# Altium schematic sheet [06] - Audio In-Out.SchDoc("[06] - Audio In-Out")
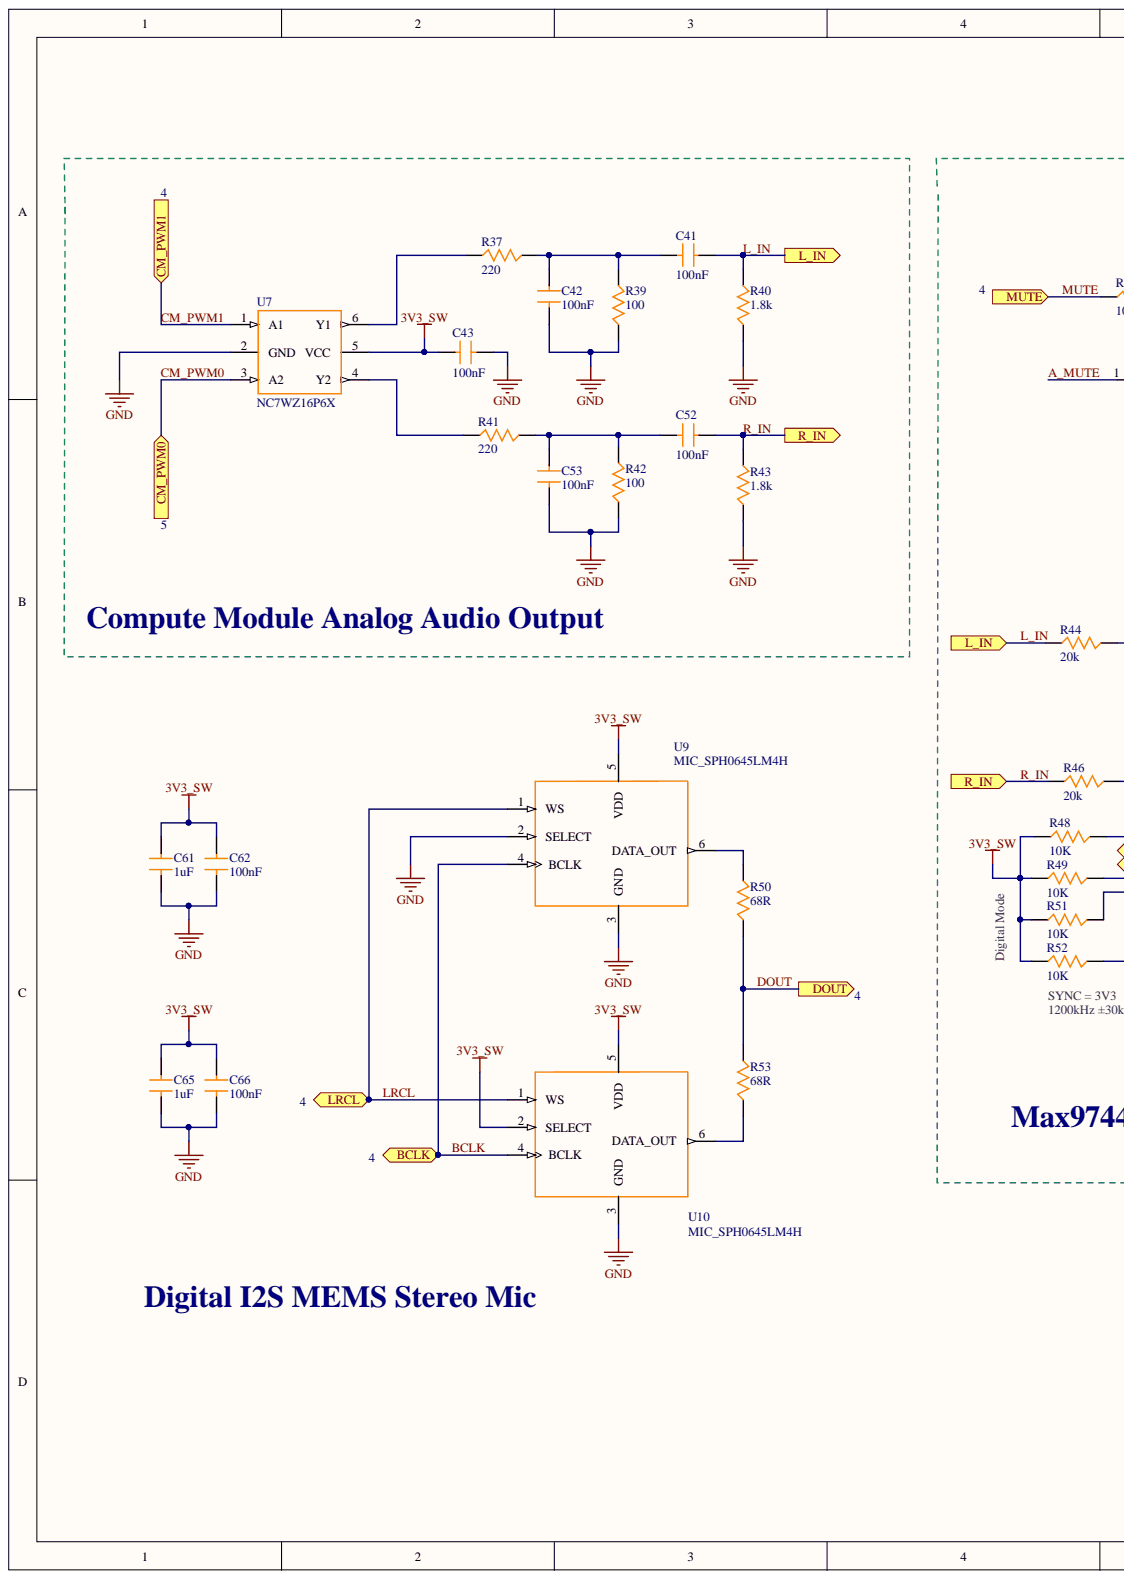
[diagram p0: [06] - Audio In-Out.SchDoc, left]
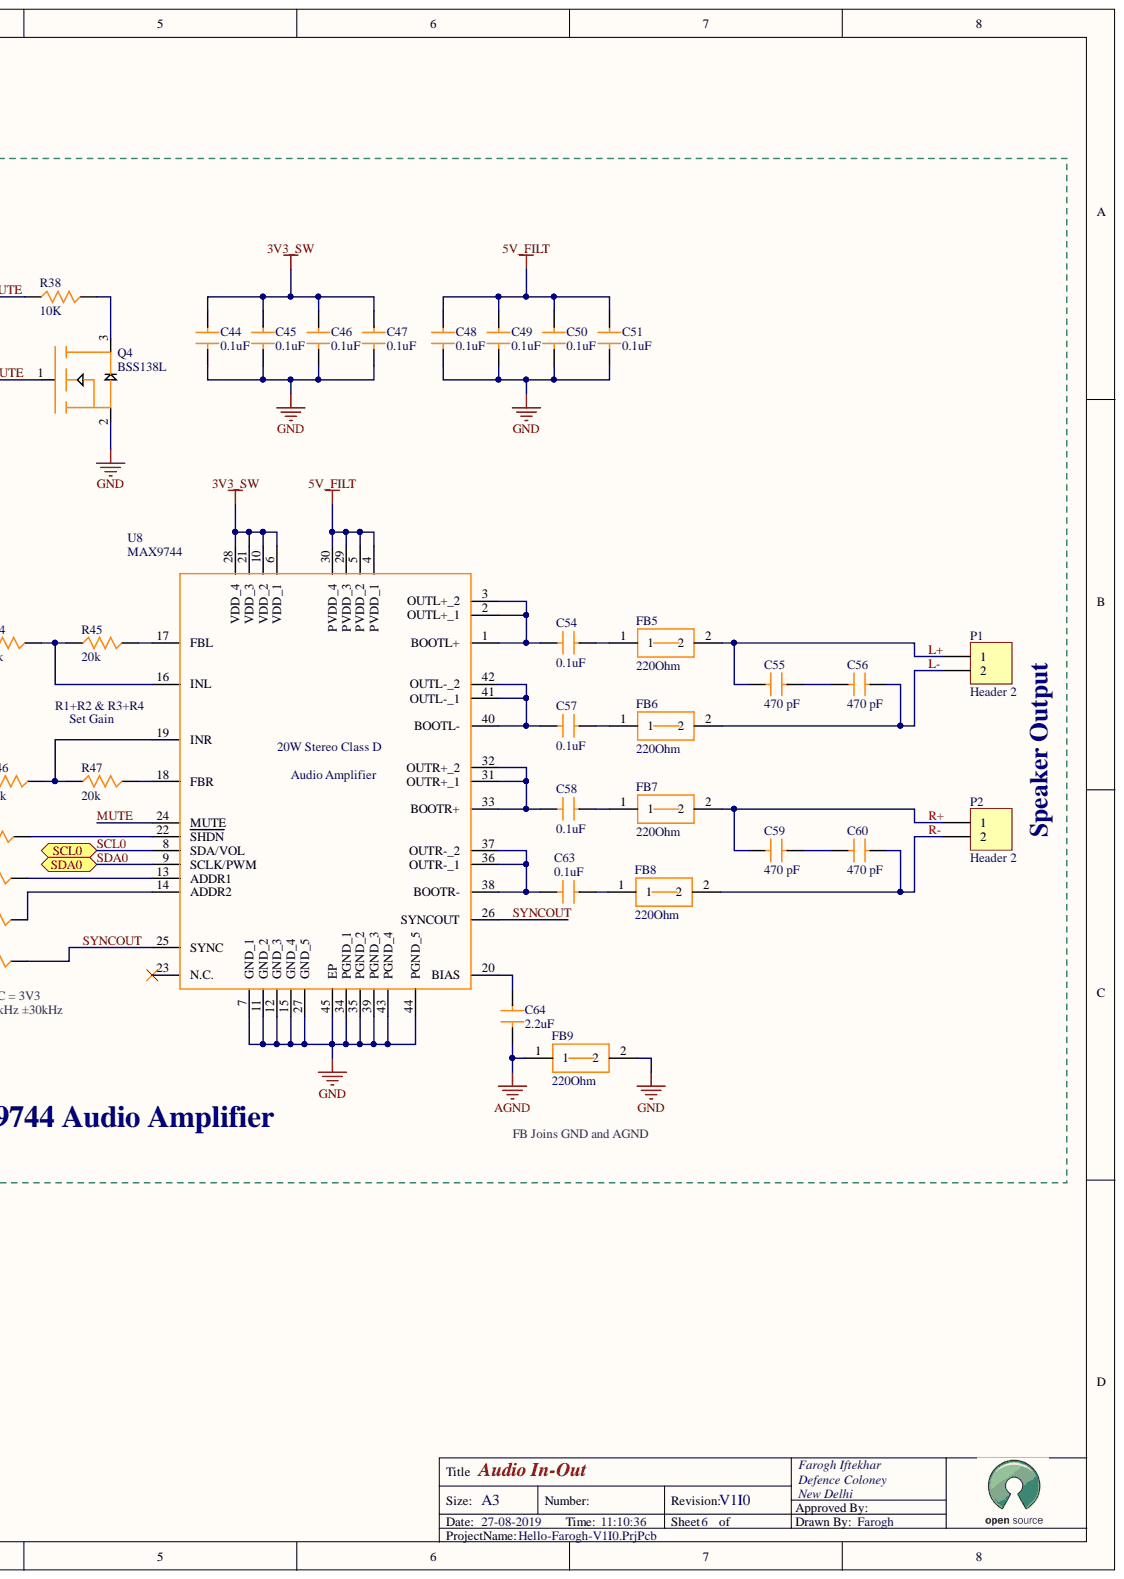
[diagram p1: [06] - Audio In-Out.SchDoc, right]

## Parts
C41  100nF  [C0805]
C42  100nF  [C0805]
C43  100nF  [C0805]
C44  0.1uF  [C0805]
C45  0.1uF  [C0805]
C46  0.1uF  [C0805]
C47  0.1uF  [C0805]
C48  0.1uF  [C0805]
C49  0.1uF  [C0805]
C50  0.1uF  [C0805]
C51  0.1uF  [C0805]
C52  100nF  [C0805]
C53  100nF  [C0805]
C54  0.1uF  [C0805]
C55  470 pF  [C0805]
C56  470 pF  [C0805]
C57  0.1uF  [C0805]
C58  0.1uF  [C0805]
C59  470 pF  [C0805]
C60  470 pF  [C0805]
C61  1uF  [C0805]
C62  100nF  [C0805]
C63  0.1uF  [C0805]
C64  2.2uF  [C1206]
C65  1uF  [C0805]
C66  100nF  [C0805]
FB5  220Ohm  [FB_0805]
FB6  220Ohm  [FB_0805]
FB7  220Ohm  [FB_0805]
FB8  220Ohm  [FB_0805]
FB9  220Ohm  [FB_0805]
P1  Header 2  [HDR1X2]
P2  Header 2  [HDR1X2]
Q4  BSS138L  [BVSS138L]
R37  220  [R0805]
R38  10K  [R0805]
R39  100  [R0805]
R40  1.8k  [R0805]
R41  220  [R0805]
R42  100  [R0805]
R43  1.8k  [R0805]
R44  20k  [R0805]
R45  20k  [R0805]
R46  20k  [R0805]
R47  20k  [R0805]
R48  10K  [R0805]
R49  10K  [R0805]
R50  68R  [R0805]
R51  10K  [R0805]
R52  10K  [R0805]
R53  68R  [R0805]
U7  NC7WZ16P6X  [SOT65P210X110-6N]
U8  MAX9744  [QFN50P700X700X80-45N]
U9  MIC_SPH0645LM4H  [SPH0645LM4H-B]
U10  MIC_SPH0645LM4H  [SPH0645LM4H-B]

## Ports
BCLK, CM_PWM0, CM_PWM1, DOUT, L_IN, LRCL, MUTE, R_IN, SCL0, SDA0

## Nets
3V3_SW: C43.2 C44.2 C45.2 C46.2 C47.2 C61.2 C62.2 C65.2 C66.2 R48.2 R49.2 R51.2 R52.2 U7.5(VCC) U8.6(VDD_1) U8.10(VDD_2) U8.21(VDD_3) U8.28(VDD_4) U9.5(VDD) U10.2(SELECT) U10.5(VDD)
5V_FILT: C48.2 C49.2 C50.2 C51.2 U8.4(PVDD_1) U8.5(PVDD_2) U8.29(PVDD_3) U8.30(PVDD_4)
AGND: C64.1 FB9.1
GND: C42.1 C43.1 C44.1 C45.1 C46.1 C47.1 C48.1 C49.1 C50.1 C51.1 C53.1 C61.1 C62.1 C65.1 C66.1 FB9.2 Q4.2 R39.1 R40.1 R42.1 R43.1 U7.2(GND) U8.7(GND_1) U8.11(GND_2) U8.12(GND_3) U8.15(GND_4) U8.27(GND_5) U8.34(PGND_1) U8.35(PGND_2) U8.39(PGND_3) U8.43(PGND_4) U8.44(PGND_5) U8.45(EP) U9.2(SELECT) U9.3(GND) U10.3(GND)
A_MUTE: Q4.1
BCLK: U9.4(BCLK) U10.4(BCLK) ; port BCLK
CM_PWM0: U7.3(A2) ; port CM_PWM0
CM_PWM1: U7.1(A1) ; port CM_PWM1
DOUT: R50.1 R53.2 ; port DOUT
L+: C55.1 FB5.2 P1.1
L-: C56.2 FB6.2 P1.2
L_IN: C41.2 R40.2 R44.1 ; port L_IN
LRCL: U9.1(WS) U10.1(WS) ; port LRCL
MUTE: R38.2 U8.24(MUTE) ; port MUTE
R+: C59.1 FB7.2 P2.1
R-: C60.2 FB8.2 P2.2
R_IN: C52.2 R43.2 R46.1 ; port R_IN
SCL0: U8.8(SDA/VOL) ; port SCL0
SDA0: U8.9(SCLK/PWM) ; port SDA0
SYNCOUT: R52.1 U8.25(SYNC) U8.26(SYNCOUT)
NetC41_1: C41.1 C42.2 R37.2 R39.2
NetC52_1: C52.1 C53.2 R41.2 R42.2
NetC54_1: C54.1 U8.1(BOOTL+) U8.2(OUTL+_1) U8.3(OUTL+_2)
NetC54_2: C54.2 FB5.1
NetC55_2: C55.2 C56.1
NetC57_1: C57.1 U8.40(BOOTL-) U8.41(OUTL-_1) U8.42(OUTL-_2)
NetC57_2: C57.2 FB6.1
NetC58_1: C58.1 U8.31(OUTR+_1) U8.32(OUTR+_2) U8.33(BOOTR+)
NetC58_2: C58.2 FB7.1
NetC59_2: C59.2 C60.1
NetC63_1: C63.1 U8.36(OUTR-_1) U8.37(OUTR-_2) U8.38(BOOTR-)
NetC63_2: C63.2 FB8.1
NetC64_2: C64.2 U8.20(BIAS)
NetQ4_3: Q4.3 R38.1
NetR37_1: R37.1 U7.6(Y1)
NetR41_1: R41.1 U7.4(Y2)
NetR44_2: R44.2 R45.1 U8.16(INL)
NetR45_2: R45.2 U8.17(FBL)
NetR46_2: R46.2 R47.1 U8.19(INR)
NetR47_2: R47.2 U8.18(FBR)
NetR48_1: R48.1 U8.22(SHDN)
NetR49_1: R49.1 U8.13(ADDR1)
NetR50_2: R50.2 U9.6(DATA_OUT)
NetR51_1: R51.1 U8.14(ADDR2)
NetR53_1: R53.1 U10.6(DATA_OUT)

Not connected: U8 23(N.C.)

## Library data of the parts
C41-C43 C52 C53 C62 C66 = C_0805-100nF-16V: Multilayer Ceramic Capacitors MLCC - SMD/SMT 100nF 16V 20% Ceramic Capacitor; Manufacturer Part Number: CC0805MRX7R7BB104; Brand: Yageo; Capacitance: 0.1 uF; Capacitance - pF: 100000 pF; Class: Class 2; Dielectric: X7R; Product Status: New at Mouser; Type: General Purpose and High Capacitance Surface Mount Ceramic Multilayer Capacitor; Voltage Rating DC: 16 VDC; Capacitance - nF: 100 nF; Case Code - in: 0805; Case Code - mm: 2012; Category: Multilayer Ceramic Capacitors MLCC - SMD/SMT; Component Kind: Standard; ComponentLink1Description: https://www.mouser.com/datasheet/2/447/UPY-GPHC_X7R_6.3V-to-50V_18-1154002.pdf; ComponentLink1URL: https://www.mouser.com/datasheet/2/447/UPY-GPHC_X7R_6.3V-to-50V_18-1154002.pdf; Height: 0.85 mm; Length: 2 mm; Manufacturer: Yageo; Maximum Operating Temperature: + 125 C; Minimum Operating Temperature: - 55 C; Minimum Order Quantity: 1; Package / Case: 0805 (2012 metric); Packaging: Cut Tape; Pricing 1: 1=0.240, 10=0.114, 100=0.057, 1000=0.049, 4000=0.036, 8000=0.034, 24000=0.033, 48000=0.031, 100000=0.026 (USD); Product: General Type MLCCs; Product Type: Ceramic Capacitors; Reeling: True; Series: CC; Standard Pack Qty: 4000; Stock 1: 15850; Subcategory: Capacitors; Supplier 1: Mouser; Supplier Part Number 1: 603-CC0805MRX77BB104; Termination: Standard; Termination Style: SMD/SMT; Tolerance: 20 %; Width: 1.25 mm; Library Name: Joule_Library.IntLib
C44-C51 C54 C57 C58 C63 = C_0805-0.1uF: Multilayer Ceramic Capacitors MLCC - SMD/SMT 0.1uF 10% 50V AEC-Q200; Manufacturer Part Number: AS0805KKX7R9BB104; Brand: Yageo; Capacitance - nF: 100 nF; Capacitance - pF: 100000 pF; CNHTS: 8532241000; Dielectric: X7R; Product Status: New Product; TARIC: 8532240000; Voltage Rating DC: 50 VDC; Capacitance: 0.1 uF; Case Code - in: 0805; Case Code - mm: 2212; Category: Multilayer Ceramic Capacitors MLCC - SMD/SMT; Component Kind: Standard; ComponentLink1Description: http://www.mouser.com/datasheet/2/447/yageodocoutput-1313910.pdf; ComponentLink1URL: http://www.mouser.com/datasheet/2/447/yageodocoutput-1313910.pdf; Height: 1.25 mm; HTS Code: 8532240020; Length: 2 mm; Manufacturer: Yageo; Maximum Operating Temperature: + 125 C; Minimum Operating Temperature: - 55 C; Minimum Order Quantity: 1; Package / Case: 0805 (2012 metric); Packaging: Cut Tape; Pricing 1: 1=0.670, 10=0.461, 100=0.262, 500=0.238, 1000=0.165, 3000=0.156, 6000=0.146, 9000=0.136, 24000=0.122 (USD); Product: Automotive MLCCs; Product Type: Ceramic Capacitors; Reeling: True; RoHS: RoHS Compliant; RoHS - Mouser: Y; Series: AS; Standard Pack Qty: 3000; Stock 1: 30283; Subcategory: Capacitors; Supplier 1: Mouser; Supplier Part Number 1: 603-AS0805KKX79BB104; Termination: Flexible (Soft); Termination Style: SMD/SMT; Tolerance: 10 %; Width: 1.25 mm; Library Name: Joule_Library.IntLib
C55 C56 C59 C60 = C_0805-470 pF: Multilayer Ceramic Capacitors MLCC - SMD/SMT 50V 470pF X7R 0805 10% AEC-Q200; Manufacturer Part Number: C0805S471K5RACAUTO; Brand: KEMET; Capacitance - nF: 0.47 nF; Product Status: New Product; Voltage Rating DC: 50 VDC; Capacitance: 470 pF; Case Code - in: 0805; Case Code - mm: 2012; Category: Multilayer Ceramic Capacitors MLCC - SMD/SMT; Component Kind: Standard; ComponentLink1Description: https://www.mouser.com/datasheet/2/212/KEM_C1014_X7R_FE-CAP_SMD-1102761.pdf; ComponentLink1URL: https://www.mouser.com/datasheet/2/212/KEM_C1014_X7R_FE-CAP_SMD-1102761.pdf; Dielectric: X7R; Height: 0.78 mm; Length: 2 mm; Manufacturer: KEMET; Maximum Operating Temperature: + 125 C; Minimum Operating Temperature: - 55 C; Minimum Order Quantity: 1; Package / Case: 0805 (2012 metric); Packaging: Cut Tape; Pricing 1: 1=0.370, 10=0.217, 100=0.131, 500=0.119, 1000=0.108, 4000=0.079, 8000=0.072, 24000=0.070, 48000=0.062 (USD); Product: Automotive MLCCs; Product Type: Ceramic Capacitors; Qualification: AEC-Q200; Reeling: True; RoHS: RoHS Compliant; RoHS - Mouser: Y; Series: SMD Auto X7R FE; Standard Pack Qty: 4000; Stock 1: 7003; Subcategory: Capacitors; Supplier 1: Mouser; Termination: Standard; Termination Style: SMD/SMT; Tolerance: 10 %; Tradename: FE-CAP; Width: 1.25 mm; Library Name: Joule_Library.IntLib
C61 C65 = C_0805-1uF: Multilayer Ceramic Capacitors MLCC - SMD/SMT 10V 1uF X7R 0805 10%; Manufacturer Part Number: C0805C105K8RAC7210; Brand: KEMET; Capacitance - nF: 1000 nF; Class: Class 2; Product Status: New Product; Voltage Rating DC: 10 VDC; Capacitance: 1 uF; Case Code - in: 0805; Case Code - mm: 2012; Category: Multilayer Ceramic Capacitors MLCC - SMD/SMT; Component Kind: Standard; ComponentLink1Description: https://www.mouser.com/datasheet/2/212/KEM_C1002_X7R_SMD-1102033.pdf; ComponentLink1URL: https://www.mouser.com/datasheet/2/212/KEM_C1002_X7R_SMD-1102033.pdf; Dielectric: X7R; Height: 0.9 mm; Length: 2 mm; Manufacturer: KEMET; Maximum Operating Temperature: + 125 C; Minimum Operating Temperature: - 55 C; Minimum Order Quantity: 1; Package / Case: 0805 (2012 metric); Packaging: Cut Tape; Pricing 1: 1=0.230, 10=0.104, 100=0.064, 500=0.057, 1000=0.048, 2000=0.041, 10000=0.036, 15000=0.032, 45000=0.028 (USD); Product: General Type MLCCs; Product Type: Ceramic Capacitors; Reeling: True; RoHS: RoHS Compliant; RoHS - Mouser: Y; Series: SMD Comm X7R; Standard Pack Qty: 15000; Stock 1: 38596; Subcategory: Capacitors; Supplier 1: Mouser; Supplier Part Number 1: 80-C0805C105K8R7210; Termination: Standard; Termination Style: SMD/SMT; Tolerance: 10 %; Width: 1.25 mm; Library Name: Joule_Library.IntLib
C64 = C_1206-2.2uF: Multilayer Ceramic Capacitors MLCC - SMD/SMT 25V 2.2uF X7R 1206 10%; Manufacturer Part Number: C1206C225K3REC7210; Brand: KEMET; Capacitance - nF: 2200 nF; Class: Class 2; CNHTS: 8532241000; Product Status: New Product; TARIC: 8532240000; Voltage Rating DC: 25 VDC; Capacitance: 2.2 uF; Case Code - in: 1206; Case Code - mm: 3216; Category: Multilayer Ceramic Capacitors MLCC - SMD/SMT; Component Kind: Standard; ComponentLink1Description: http://www.mouser.com/datasheet/2/212/KEM_C1090_X7R_ESD-1103328.pdf; ComponentLink1URL: http://www.mouser.com/datasheet/2/212/KEM_C1090_X7R_ESD-1103328.pdf; Dielectric: X7R; Height: 1.6 mm; HTS Code: 8532240020; Length: 3.2 mm; Manufacturer: KEMET; Maximum Operating Temperature: + 125 C; Minimum Operating Temperature: - 55 C; Minimum Order Quantity: 1; Package / Case: 1206 (3216 metric); Packaging: Cut Tape; Pricing 1: 1=0.230, 10=0.121, 100=0.073, 500=0.065, 1000=0.051, 5000=0.045, 8000=0.041, 24000=0.039, 48000=0.035 (USD); Product: General Type MLCCs; Product Type: Ceramic Capacitors; Reeling: True; RoHS: RoHS Compliant; RoHS - Mouser: Y; Series: ESD SMD Comm X7R; Standard Pack Qty: 8000; Stock 1: 7554; Subcategory: Capacitors; Supplier 1: Mouser; Supplier Part Number 1: 80-C1206C225K3RECLR; Termination: Standard; Termination Style: SMD/SMT; Tolerance: 10 %; Width: 1.6 mm; Library Name: Joule_Library.IntLib
FB5-FB9 = FB_0805-220, 3000mA: Ferrite Beads NEW MFG PN 810-MPZ2012S221AT000; Brand: TDK; Impedance: 220 Ohms; Maximum DC Current: 3 A; Maximum DC Resistance: 40 mOhms; Product Status: Part Number Change; Test Frequency: 100 MHz; Tolerance: 25 %; Type: Chip Bead SMD for Power Line; Capacitance: -; Category: Ferrite Beads; Component Kind: Standard; ComponentLink1Description: https://www.mouser.com/datasheet/2/400/eads_commercial_power_mpz2012_en-923646.pdf; ComponentLink1URL: https://www.mouser.com/datasheet/2/400/eads_commercial_power_mpz2012_en-923646.pdf; Height: 0.85 mm; Length: 2 mm; Manufacturer: TDK; Manufacturer Part Number: MPZ2012S221A; Maximum Operating Temperature: + 125 C; Minimum Operating Temperature: - 55 C; Minimum Order Quantity: 1; Operating Temperature Range: - 55 C to + 125 C; Package / Case: 0805 (2012 metric); Packaging: Cut Tape; Pricing 1: 1=0.120, 10=0.101, 100=0.046, 500=0.039, 1000=0.033, 4000=0.028, 8000=0.024, 48000=0.023 (USD); Product: Ferrite Chip Beads; Product Type: Ferrite Beads; Reeling: True; RoHS: RoHS Compliant; RoHS - Mouser: Y; Series: MPZ; Standard Pack Qty: 4000; Stock 1: 24645; Subcategory: Ferrites; Supplier 1: Mouser; Supplier Part Number 1: 810-MPZ2012S221A; Termination: -; Termination Style: SMD/SMT; Width: 1.25 mm; Library Name: Joule_Library.IntLib
P1 P2 = Header 2: Header, 2-Pin; Component Kind: Standard; LatestRevisionDate: 17-Jul-2002; LatestRevisionNote: Re-released for DXP Platform.; Library Name: Miscellaneous Connectors.IntLib; Signal Integrity: Connector; Publisher: Altium Limited
Q4 = BSS138L: MOSFET FET 50V 3.5 OHM SOT23; Brand: ON Semiconductor / Fairchild; Channel Mode: Enhancement; CNHTS: 8541290000; Configuration: Single; Fall Time: 5.1 ns; Forward Transconductance - Min: 120 mS; Id - Continuous Drain Current: 200 mA; MXHTS: 85412999; Number of Channels: 1 Channel; Pd - Power Dissipation: 350 mW; Qg - Gate Charge: 549 pC; Rise Time: 1.8 ns; Transistor Polarity: N-Channel; Transistor Type: 1 N-Channel; Typical Turn-Off Delay Time: 5.3 ns; Typical Turn-On Delay Time: 2.2 ns; Vgs - Gate-Source Voltage: 20 V; Vgs th - Gate-Source Threshold Voltage: 1.25 V; Category: MOSFET; Component Kind: Standard; ComponentLink1Description: http://www.mouser.com/datasheet/2/308/BSS138L-1300146.pdf; ComponentLink1URL: http://www.mouser.com/datasheet/2/308/BSS138L-1300146.pdf; Height: 1.2 mm; HTS Code: 8541210095; Length: 2.9 mm; Manufacturer: ON Semiconductor / Fairchild; Manufacturer Part Number: BSS138L; Maximum Operating Temperature: + 150 C; Minimum Operating Temperature: - 55 C; Minimum Order Quantity: 1; Mounting Style: SMD/SMT; Package / Case: SOT-23-3; Packaging: Cut Tape; Pricing 1: 1=0.260, 10=0.180, 100=0.075, 1000=0.052, 3000=0.040, 9000=0.035, 24000=0.032, 45000=0.030 (USD); Product Type: MOSFET; Rds On - Drain-Source Resistance: 3.5 Ohms; Reeling: True; RoHS: RoHS Compliant; RoHS - Mouser: Y; Series: BSS138L; Standard Pack Qty: 3000; Stock 1: 227181; Subcategory: MOSFETs; Supplier 1: Mouser; Supplier Part Number 1: 512-BSS138L; TARIC: 8541290000; Technology: Si; Vds - Drain-Source Breakdown Voltage: 50 V; Width: 1.3 mm; Library Name: Joule_Library.IntLib
R37 R41 = R_0805-220: Thin Film Resistors - SMD; Manufacturer Part Number: RT0805DRE07220RL; Brand: Yageo; CNHTS: 8533211000; MXHTS: 85332101; Power Rating: 125 mW (1/8 W); Resistance: 220 Ohms; TARIC: 8533210000; Temperature Coefficient: 50 PPM / C; Tolerance: 0.5 %; Voltage Rating: 150 V; Case Code - in: 0805; Case Code - mm: 2012; Category: Thin Film Resistors - SMD; Component Kind: Standard; ComponentLink1Description: https://www.mouser.com/datasheet/2/447/PYu-RT_1-to-0.01_RoHS_L_9-1222720.pdf; ComponentLink1URL: https://www.mouser.com/datasheet/2/447/PYu-RT_1-to-0.01_RoHS_L_9-1222720.pdf; Features: -; Height: 0.5 mm; HTS Code: 8533210030; Length: 2 mm; Manufacturer: Yageo; Maximum Operating Temperature: + 125 C; Minimum Operating Temperature: - 55 C; Minimum Order Quantity: 1; Mounting Style: PCB Mount; Operating Temperature Range: - 55 C to + 125 C; Packaging: Cut Tape; Pricing 1: 1=0.170, 10=0.088, 100=0.031, 1000=0.022, 5000=0.015, 10000=0.014, 25000=0.013, 50000=0.012 (USD); Product: Thin Film Resistors SMD; Product Type: Thin Film Resistors; Reeling: True; RoHS: RoHS Compliant; RoHS - Mouser: Y; Series: RT; Standard Pack Qty: 5000; Stock 1: 14351; Subcategory: Resistors; Supplier 1: Mouser; Supplier Part Number 1: 603-RT0805DRE07220RL; Technology: Thin Film; Termination Style: SMD/SMT; Type: High Precision, High Stability; Width: 1.2 mm; Library Name: Joule_Library.IntLib
R38 R48 R49 R51 R52 = R_0805-10K: Thick Film Resistors - SMD 10K   OHM   1% 1/4W; Manufacturer Part Number: RC0805FR-7W10KL; Brand: Yageo; CNHTS: 8533211000; MXHTS: 85332101; Power Rating: 125 mW, 250 mW; Resistance: 10 kOhms; TARIC: 8533210000; Temperature Coefficient: 100 PPM / C; Voltage Rating: 150 V; Application: High Reliability; Case Code - in: 0805; Case Code - mm: 2012; Category: Thick Film Resistors - SMD; Component Kind: Standard; ComponentLink1Description: http://www.mouser.com/datasheet/2/447/yageo_01152019_DESIGN_KITS_8_2012-1522572.pdf; ComponentLink1URL: http://www.mouser.com/datasheet/2/447/yageo_01152019_DESIGN_KITS_8_2012-1522572.pdf; Features: -; Height: 0.5 mm; HTS Code: 8533210030; Length: 2 mm; Manufacturer: Yageo; Maximum Operating Temperature: + 155 C; Minimum Operating Temperature: - 55 C; Minimum Order Quantity: 1; Mounting Style: PCB Mount; Package / Case: 0805 (2012 metric); Packaging: Cut Tape; Pricing 1: 1=0.190, 10=0.115, 100=0.038, 1000=0.026, 5000=0.020, 10000=0.019, 25000=0.018, 100000=0.017 (USD); Product: Thick Film Resistors SMD; Product Type: Thick Film Resistors; Reeling: True; RoHS: RoHS Compliant; RoHS - Mouser: Y; Series: RC; Standard Pack Qty: 5000; Stock 1: 8240; Subcategory: Resistors; Supplier 1: Mouser; Supplier Part Number 1: 603-RC0805FR-7W10KL; Technology: Thick Film; Termination Style: SMD/SMT; Tolerance: 1 %; Type: High Power; Width: 1.25 mm; Library Name: Joule_Library.IntLib
R39 R42 = R_0805-100: Thin Film Resistors - SMD 100Ohm,0805,1%,25ppm ,100mW,100V; Manufacturer Part Number: RN732ATTDK1000F25; Brand: KOA Speer; CNHTS: 8533211000; MXHTS: 85332101; Power Rating: 100 mW (1/10 W); Resistance: 100 Ohms; TARIC: 8533210000; Temperature Coefficient: 25 PPM / C; Voltage Rating: 150 V; Case Code - in: 0805; Case Code - mm: 2012; Category: Thin Film Resistors - SMD; Component Kind: Standard; ComponentLink1Description: http://www.mouser.com/datasheet/2/219/RN73-11994.pdf; ComponentLink1URL: http://www.mouser.com/datasheet/2/219/RN73-11994.pdf; Features: -; Height: 0.5 mm; HTS Code: 8533210030; Length: 2 mm; Manufacturer: KOA Speer; Maximum Operating Temperature: + 155 C; Minimum Operating Temperature: - 55 C; Minimum Order Quantity: 1; Operating Temperature Range: - 55 C to + 155 C; Package / Case: 0805 (2012 metric); Packaging: Cut Tape; Pricing 1: 1=0.230, 25=0.133, 50=0.127, 100=0.118, 250=0.115, 500=0.107, 1000=0.105, 2000=0.099 (USD); Product: Precision Resistors Thin Film SMD; Product Type: Thin Film Resistors; Reeling: True; RoHS: RoHS Compliant; RoHS - Mouser: Y; Series: RN73; Standard Pack Qty: 1000; Stock 1: 1015; Subcategory: Resistors; Supplier 1: Mouser; Supplier Part Number 1: 660-RN732ATTDK1000F2; Termination Style: SMD/SMT; Tolerance: 1 %; Type: Thin Film (Metal) Chip Resistor-Ultra High Precision Tolerance; Width: 1.25 mm; Library Name: Joule_Library.IntLib
R40 R43 = R_0805-1.8K: Thin Film Resistors - SMD; Manufacturer Part Number: RT0805CRE071K8L; Brand: Yageo; CNHTS: 8533211000; MXHTS: 85332101; Power Rating: 125 mW (1/8 W); Resistance: 1.8 kOhms; TARIC: 8533210000; Temperature Coefficient: 50 PPM / C; Tolerance: 0.25 %; Voltage Rating: 150 V; Case Code - in: 0805; Case Code - mm: 2012; Category: Thin Film Resistors - SMD; Component Kind: Standard; ComponentLink1Description: http://www.mouser.com/datasheet/2/447/PYu-RT_1-to-0.01_RoHS_L_9-1222720.pdf; ComponentLink1URL: http://www.mouser.com/datasheet/2/447/PYu-RT_1-to-0.01_RoHS_L_9-1222720.pdf; Features: -; Height: 0.5 mm; HTS Code: 8533210030; Length: 2 mm; Manufacturer: Yageo; Maximum Operating Temperature: + 125 C; Minimum Operating Temperature: - 55 C; Minimum Order Quantity: 1; Operating Temperature Range: - 55 C to + 125 C; Package / Case: 0805 (2012 metric); Packaging: Cut Tape; Pricing 1: 1=0.430, 10=0.249, 100=0.100, 1000=0.076, 5000=0.059, 10000=0.057, 25000=0.055, 50000=0.054 (USD); Product: Thin Film Resistors SMD; Reeling: True; RoHS: RoHS Compliant; RoHS - Mouser: Y; Series: RT; Standard Pack Qty: 5000; Stock 1: 4680; Supplier 1: Mouser; Supplier Part Number 1: 603-RT0805CRE071K8L; Termination Style: SMD/SMT; Type: High Precision, High Stability; Width: 1.25 mm; Library Name: Joule_Library.IntLib
R44-R47 = R_0805-20K: Thin Film Resistors - SMD 20 Kohms 0.1% Tol. AEC-Q200; Manufacturer Part Number: AT0805BRD0720KL; Brand: Yageo; CNHTS: 8533211000; MXHTS: 85332101; Power Rating: 125 mW (1/8 W); TARIC: 8533210000; Temperature Coefficient: 25 PPM / C; Voltage Rating: 150 V; Case Code - in: 0805; Case Code - mm: 2012; Category: Thin Film Resistors - SMD; Component Kind: Standard; ComponentLink1Description: http://www.mouser.com/datasheet/2/447/PYu-AT_51_RoHS_L_5-1222714.pdf; ComponentLink1URL: http://www.mouser.com/datasheet/2/447/PYu-AT_51_RoHS_L_5-1222714.pdf; Diameter: -; Features: -; Height: 0.5 mm; HTS Code: 8533210030; Length: 2 mm; Manufacturer: Yageo; Maximum Operating Temperature: + 125 C; Minimum Operating Temperature: - 55 C; Minimum Order Quantity: 1; Operating Temperature Range: - 55 C to + 125 C; Package / Case: 0805 (2012 metric); Packaging: Cut Tape; Pricing 1: 1=0.590, 10=0.416, 100=0.236, 1000=0.191, 5000=0.164, 10000=0.159, 25000=0.155, 50000=0.154 (USD); Product: Thin Film Resistors SMD; Qualification: AEC-Q200; Reeling: True; Resistance: 20 kOhms; RoHS: RoHS Compliant; RoHS - Mouser: Y; Series: AT; Standard Pack Qty: 5000; Stock 1: 4947; Supplier 1: Mouser; Supplier Part Number 1: 603-AT0805BRD0720KL; Termination Style: SMD/SMT; Tolerance: 0.1 %; Type: High Precision High Stability Chip Resistors; Width: 1.25 mm; Library Name: Joule_Library.IntLib
R50 R53 = R_0805-68R: Thick Film Resistors - SMD 1/8Watt 68ohms 1% Commercial Use; Manufacturer Part Number: CRCW080568R0FKEAC; Brand: Vishay / Dale; Power Rating: 125 mW (1/8 W); Product Status: New Product; Temperature Coefficient: 100 PPM / C; Voltage Rating: 150 V; Case Code - in: 0805; Case Code - mm: 2012; Category: Thick Film Resistors - SMD; Component Kind: Standard; ComponentLink1Description: https://www.mouser.com/datasheet/2/427/crcwce3-1223726.pdf; ComponentLink1URL: https://www.mouser.com/datasheet/2/427/crcwce3-1223726.pdf; Features: -; Length: 2 mm; Manufacturer: Vishay / Dale; Maximum Operating Temperature: + 155 C; Minimum Operating Temperature: - 55 C; Minimum Order Quantity: 1; Mounting Style: PCB Mount; Package / Case: -; Packaging: Cut Tape; Pricing 1: 1=0.180, 10=0.083, 100=0.048, 500=0.026, 1000=0.015, 5000=0.010, 10000=0.007, 25000=0.006, 50000=0.005 (USD); Product: Thick Film Resistors SMD; Product Type: Thick Film Resistors; Reeling: True; Resistance: 68 Ohms; RoHS: RoHS Compliant By Exemption; RoHS - Mouser: E; Series: CRCW-C e3; Standard Pack Qty: 5000; Stock 1: 27467; Subcategory: Resistors; Supplier 1: Mouser; Technology: Thick Film; Termination Style: SMD/SMT; Tolerance: 1 %; Width: 1.25 mm; Library Name: Joule_Library.IntLib
U7 = NC7WZ16P6X: Buffers & Line Drivers UHS Dual Buffer; Brand: ON Semiconductor / Fairchild; High Level Output Current: - 32 mA; Input Signal Type: Single-Ended; Logic Family: TinyLogic UHS; Logic Type: CMOS; Low Level Output Current: 32 mA; Number of Channels: 2; Number of Input Lines: 2 Input; Number of Output Lines: 2 Output; Operating Supply Voltage: 1.65 V to 5.5 V; Pd - Power Dissipation: 180 mW; Polarity: Non-Inverting; Propagation Delay Time: 4.6 ns at 3.3 V, 3.8 ns at 5 V; Quiescent Current: 1 uA; Supply Voltage - Max: 5.5 V; Supply Voltage - Min: 1.65 V; Category: Buffers & Line Drivers; Component Kind: Standard; ComponentLink1Description: https://www.mouser.com/datasheet/2/308/NC7WZ16-1301496.pdf; ComponentLink1URL: https://www.mouser.com/datasheet/2/308/NC7WZ16-1301496.pdf; Function: Buffer; Height: 1 mm; Length: 2 mm; Manufacturer: ON Semiconductor / Fairchild; Manufacturer Part Number: NC7WZ16P6X; Maximum Operating Temperature: + 85 C; Minimum Operating Temperature: - 40 C; Minimum Order Quantity: 1; Mounting Style: SMD/SMT; Package / Case: SC-70-6; Packaging: Cut Tape; Pricing 1: 1=0.440, 10=0.285, 100=0.122, 1000=0.093, 3000=0.071, 9000=0.063, 24000=0.059, 45000=0.057 (USD); Product Type: Buffers & Line Drivers; Reeling: True; RoHS: RoHS Compliant; RoHS - Mouser: Y; Series: NC7WZ16; Standard Pack Qty: 3000; Stock 1: 31573; Subcategory: Logic ICs; Supplier 1: Mouser; Supply Current - Max: 1 uA; Technology: CMOS; Tradename: TinyLogic; Width: 1.25 mm; Library Name: Joule_Library.IntLib
U8 = MAX9744ETH+T: Audio Amplifiers Stereo 20W Class D Amplifier; Audio - Load Impedance: 4 Ohms; Brand: Maxim Integrated; Class: Class-D; Operating Supply Current: 20 mA; Pd - Power Dissipation: 2963 mW; PSRR - Power Supply Rejection Ratio: 75 dB; THD plus Noise: 0.04 %; Category: Audio Amplifiers; Component Kind: Standard; ComponentLink1Description: https://www.mouser.com/datasheet/2/256/MAX9744-1513525.pdf; ComponentLink1URL: https://www.mouser.com/datasheet/2/256/MAX9744-1513525.pdf; Manufacturer: Maxim Integrated; Manufacturer Part Number: MAX9744ETH+T; Maximum Operating Temperature: + 85 C; Minimum Operating Temperature: - 40 C; Minimum Order Quantity: 1; Output Power: 20 W; Packaging: Cut Tape; Pricing 1: 1=5.32, 10=4.77, 25=4.51, 50=3.95, 100=3.91, 250=3.71, 500=3.32, 1000=2.56, 2500=2.56 (USD); Product: Audio Amplifiers; Product Type: Audio Amplifiers; Reeling: True; RoHS: RoHS Compliant; RoHS - Mouser: Y; Series: MAX9744; Standard Pack Qty: 2500; Stock 1: 6566; Subcategory: Audio ICs; Supplier 1: Mouser; Library Name: Joule_Library.IntLib
U9 U10 = MIC_SPH0645LM4H-B: MEMS Microphones DIG MEMs Mic, BP w/ I2S output; Brand: Knowles; Directional Properties: Omnidirectional; Frequency Range: 10 Hz to 10 kHz; Operating Supply Current: 600 uA; Operating Supply Voltage: 3.6 V; Output Type: Digital; Port Type: Bottom; Sensitivity: - 26 dB; Shape: Rectangular; SNR - Signal to Noise Ratio: 65 dB; Sound Pressure Level: 120 dB; Supply Voltage - Min: 1.62 V; Tolerance: 1 dB; Category: MEMS Microphones; Component Kind: Standard; ComponentLink1Description: https://www.mouser.com/datasheet/2/218/ph0645lm4h-b-datasheet-rev-c-1525723.pdf; ComponentLink1URL: https://www.mouser.com/datasheet/2/218/ph0645lm4h-b-datasheet-rev-c-1525723.pdf; Depth: 1.08 mm; Impedance: -; Length: 3.5 mm; Manufacturer: Knowles; Manufacturer Part Number: SPH0645LM4H-B; Maximum Operating Temperature: + 100 C; Minimum Operating Temperature: - 40 C; Minimum Order Quantity: 1; Mounting Style: SMD/SMT; Packaging: Cut Tape; Pricing 1: 1=2.64, 10=2.28, 25=1.80, 100=1.73, 250=1.71, 500=1.58, 1000=1.56, 2500=1.38, 5900=1.27 (USD); Product Type: MEMS Microphones; Reeling: True; RoHS: RoHS Compliant; RoHS - Mouser: Y; Series: SPH; Standard Pack Qty: 5900; Stock 1: 37799; Subcategory: Microphones; Supplier 1: Mouser; Supply Voltage - Max: 3.6 V; Technology: MEMS; Termination Style: SMD/SMT; Tradename: SiSonic; Type: Silicon MEMS Microphone; Width: 2.65 mm; Library Name: Joule_Library.IntLib
